# Altium schematic sheet 04_ATK_DLRK3506J_Audio_30PIN.SchDoc
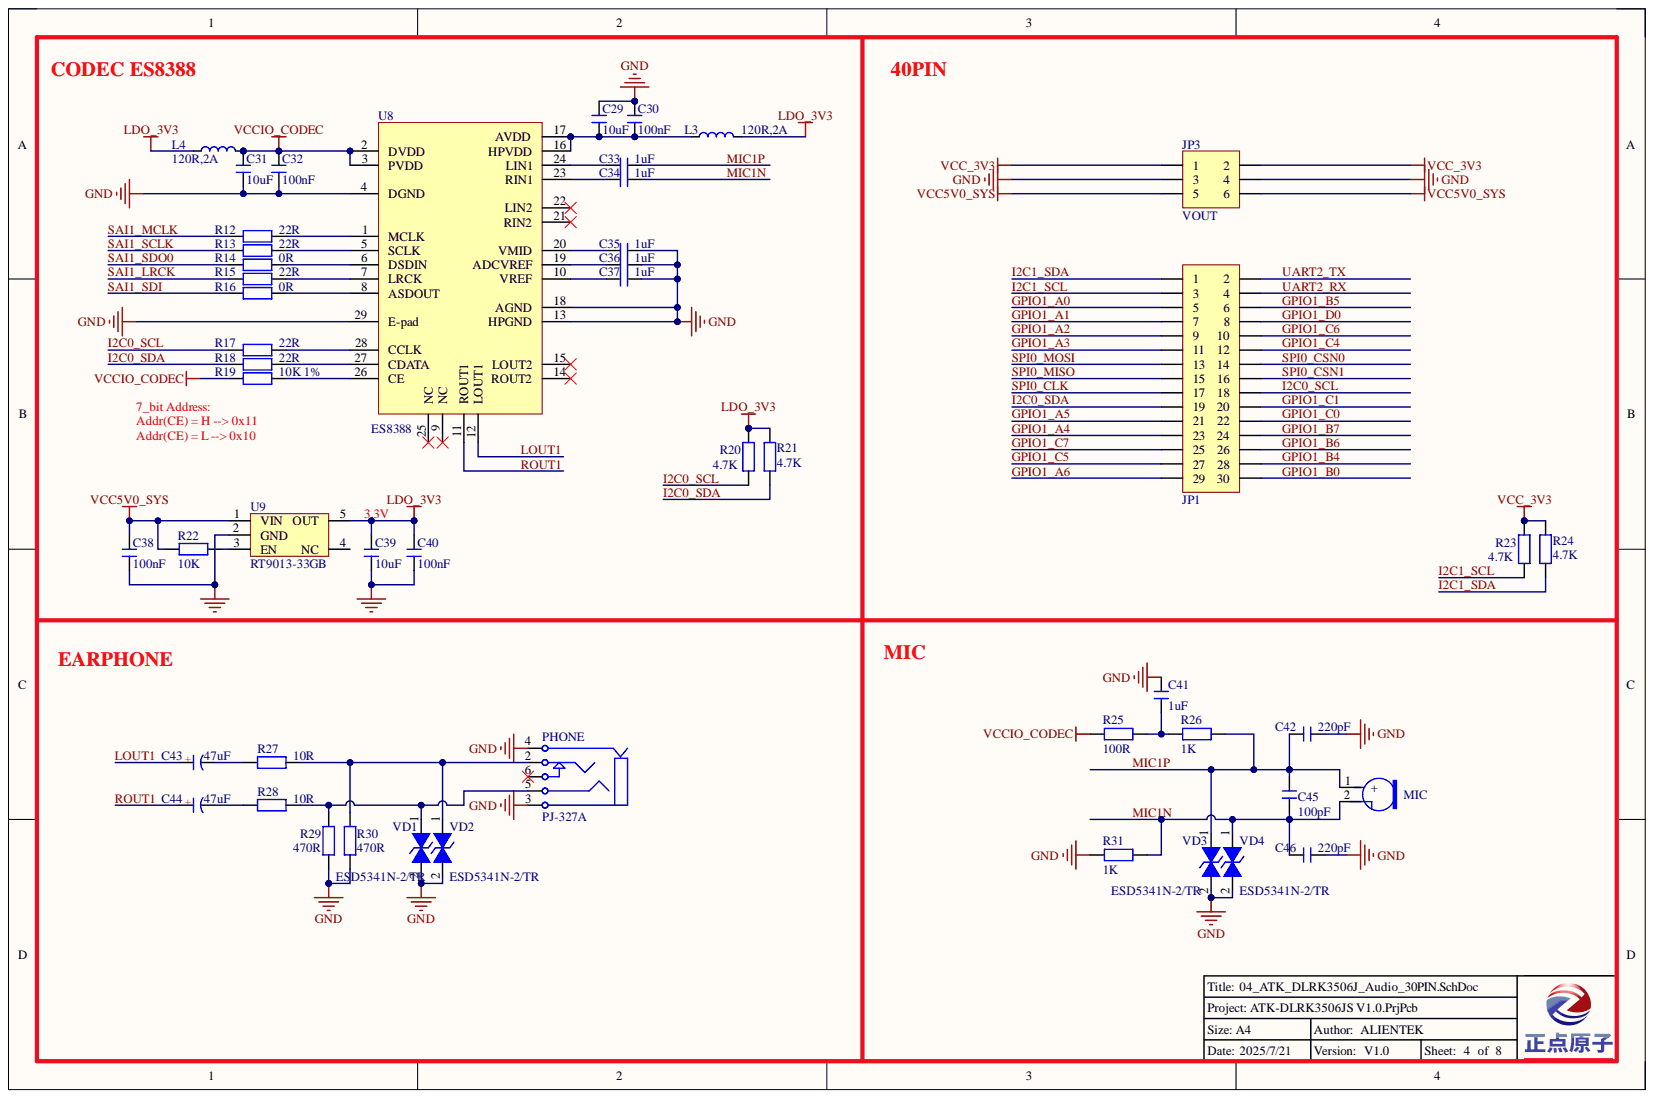
[diagram p0: 04_ATK_DLRK3506J_Audio_30PIN.SchDoc]

## Parts
C29  10uF  [C 0402]
C30  100nF  [C 0402]
C31  10uF  [C 0402]
C32  100nF  [C 0402]
C33  1uF  [C 0402]
C34  1uF  [C 0402]
C35  1uF  [C 0402]
C36  1uF  [C 0402]
C37  1uF  [C 0402]
C38  100nF  [C 0402]
C39  10uF  [C 0402]
C40  100nF  [C 0402]
C41  1uF  [C 0402]
C42  220pF  [C 0402]
C43  47uF  [CM B(4*5.4)]
C44  47uF  [CM B(4*5.4)]
C45  100pF  [C 0402]
C46  220pF  [C 0402]
JP1  Header 2X15  [Header 2.54 2X15P]  (value not drawn)
JP3  VOUT  [Header 2.54 2X3P]
L3  120R,2A  [L 0603]
L4  120R,2A  [L 0603]
MIC  MIC-6022  [MIC-6022]  (value not drawn)
PHONE  PJ-327A  [PJ327A]
R12  22R  [R 0402]
R13  22R  [R 0402]
R14  0R  [R 0402]
R15  22R  [R 0402]
R16  0R  [R 0402]
R17  22R  [R 0402]
R18  22R  [R 0402]
R19  10K 1%  [R 0402]
R20  4.7K  [R 0402]
R21  4.7K  [R 0402]
R22  10K  [R 0402]
R23  4.7K  [R 0402]
R24  4.7K  [R 0402]
R25  100R  [R 0402]
R26  1K  [R 0402]
R27  10R  [R 0402]
R28  10R  [R 0402]
R29  470R  [R 0402]
R30  470R  [R 0402]
R31  1K  [R 0402]
U8  ES8388  [QFN28 4X4_L]
U9  RT9013-33GB  [SOT23-5N]
VD1  ESD5341N-2/TR  [ESD0402]
VD2  ESD5341N-2/TR  [ESD0402]
VD3  ESD5341N-2/TR  [ESD0402]
VD4  ESD5341N-2/TR  [ESD0402]

## Nets
GND: C29.2 C30.2 C31.1 C32.1 C35.1 C36.1 C37.1 C38.1 C39.1 C40.1 C41.1 C42.2 C46.2 JP3.3 JP3.4 PHONE.3 PHONE.4 R29.2 R30.2 R31.1 U8.4(DGND) U8.13(HPGND) U8.18(AGND) U8.29(E-pad) U9.2(GND) VD1.2 VD2.2 VD3.2 VD4.2
LDO_3V3: C39.2 C40.2 L3.2 L4.1 R20.1 R21.1 U9.5(OUT)
VCC5V0_SYS: C38.2 JP3.5 JP3.6 R22.1 U9.1(VIN)
VCC_3V3: JP3.1 JP3.2 R23.1 R24.1
VCCIO_CODEC: C31.2 C32.2 L4.2 R19.2 R25.2 U8.2(DVDD) U8.3(PVDD)
GPIO1_A0: JP1.5
GPIO1_A1: JP1.7
GPIO1_A2: JP1.9
GPIO1_A3: JP1.11
GPIO1_A4: JP1.23
GPIO1_A5: JP1.21
GPIO1_A6: JP1.29
GPIO1_B0: JP1.30
GPIO1_B4: JP1.28
GPIO1_B5: JP1.6
GPIO1_B6: JP1.26
GPIO1_B7: JP1.24
GPIO1_C0: JP1.22
GPIO1_C1: JP1.20
GPIO1_C4: JP1.12
GPIO1_C5: JP1.27
GPIO1_C6: JP1.10
GPIO1_C7: JP1.25
GPIO1_D0: JP1.8
I2C0_SCL: JP1.18 R17.2 R20.2
I2C0_SDA: JP1.19 R18.2 R21.2
I2C1_SCL: JP1.3 R23.2
I2C1_SDA: JP1.1 R24.2
LOUT1: C43.1 U8.12(LOUT1)
MIC1N: C34.1 C45.2 C46.1 MIC.2 R31.2 VD4.1
MIC1P: C33.1 C42.1 C45.1 MIC.1 R26.1 VD3.1
ROUT1: C44.1 U8.11(ROUT1)
SAI1_LRCK: R15.2
SAI1_MCLK: R12.2
SAI1_SCLK: R13.2
SAI1_SDI: R16.2
SAI1_SDO0: R14.2
SPI0_CLK: JP1.17
SPI0_CSN0: JP1.14
SPI0_CSN1: JP1.16
SPI0_MISO: JP1.15
SPI0_MOSI: JP1.13
UART2_RX: JP1.4
UART2_TX: JP1.2
NetC29_1: C29.1 C30.1 L3.1 U8.16(HPVDD) U8.17(AVDD)
NetC33_2: C33.2 U8.24(LIN1)
NetC34_2: C34.2 U8.23(RIN1)
NetC35_2: C35.2 U8.20(VMID)
NetC36_2: C36.2 U8.19(ADCVREF)
NetC37_2: C37.2 U8.10(VREF)
NetC41_2: C41.2 R25.1 R26.2
NetC43_2: C43.2 R27.2
NetC44_2: C44.2 R28.2
NetPHONE_2: PHONE.2 R27.1 R30.1 VD2.1
NetPHONE_5: PHONE.5 R28.1 R29.1 VD1.1
NetR12_1: R12.1 U8.1(MCLK)
NetR13_1: R13.1 U8.5(SCLK)
NetR14_1: R14.1 U8.6(DSDIN)
NetR15_1: R15.1 U8.7(LRCK)
NetR16_1: R16.1 U8.8(ASDOUT)
NetR17_1: R17.1 U8.28(CCLK)
NetR18_1: R18.1 U8.27(CDATA)
NetR19_1: R19.1 U8.26(CE)
NetR22_2: R22.2 U9.3(EN)

Not connected: PHONE 6; U8 9(NC) 14(ROUT2) 15(LOUT2) 21(RIN2) 22(LIN2) 25(NC); U9 4(NC)

## Library data of the parts
C29-C42 C45 C46 = C: 无极性贴片电容; 版权归属: 源创客; BOM名称: 无极性贴片电容; Component Kind: Standard; Library Name: 电阻-电容-电感.SchLib; 学习资源: www.ooocke.com
C43 C44 = C-CM: 贴片电解电容; 版权归属: 源创客; BOM名称: 贴片电解电容; Component Kind: Standard; Library Name: 电阻-电容-电感.SchLib; 学习资源: www.ooocke.com
JP1 = Header 15X2: 150*2P接插件; BOM名称: 20*2P接插件; Parameter: 接插件; 版权归属: 正点原子; Component Kind: Standard; Library Name: ATK-DLRK3506JS V0.1.SCHLIB; 学习资源: www.openedv.com
JP3 = Header 3X2: 3*2P接插件; Parameter: 接插件; 版权归属: 正点原子; BOM名称: 3*2P接插件; Component Kind: Standard; Library Name: 正点原子封装库V1.0.IntLib; 学习资源: www.openedv.com
L3 L4 = L: 小功率贴片电感磁珠; 版权归属: 正点原子; BOM名称: 小功率贴片电感; Component Kind: Standard; Library Name: 电阻-电容-电感.SchLib; 学习资源: www.openedv.com
MIC = MIC-6022: MIC; Parameter: 接口器件; 版权归属: 正点原子; Component Kind: Standard; Library Name: 正点原子封装库V1.0.IntLib; 学习资源: www.openedv.com
PHONE = Phone-PJ-327A: 3.5mm耳机座，带拔插检测; Parameter: 接口器件; 版权归属: 正点原子; Component Kind: Standard; Library Name: 正点原子封装库V1.0.IntLib; 学习资源: www.openedv.com
R12-R30 = R: 贴片电阻; BOM名称: 电阻; 版权归属: 正点原子; Component Kind: Standard; Library Name: 电阻-电容-电感.SchLib; 学习资源: www.openedv.com
R31 = R: 电阻; BOM名称: 贴片电阻; 版权归属: 正点原子; Component Kind: Standard; Library Name: 正点原子封装库V1.0.IntLib; Parameter: 电阻; 学习资源: www.openedv.com
U9 = RT9013: LDO 5.5V 500mA; BOM名称: 稳压芯片; Parameter: 芯片; 版权归属: 正点原子; Component Kind: Standard; 学习资源: www.openedv.com; Library Name: Lycreturn.IntLib
VD1-VD4 = ESD5341N: ESD二极管-单向; Component Kind: Standard; Library Name: ZZK_COMPONENT.IntLib
U8 = ES8388: Component Kind: Standard; Library Name: ZZK_COMPONENT.IntLib
